# Altium schematic sheet pultboard_cpu.SchDoc(pultboard_cpu)
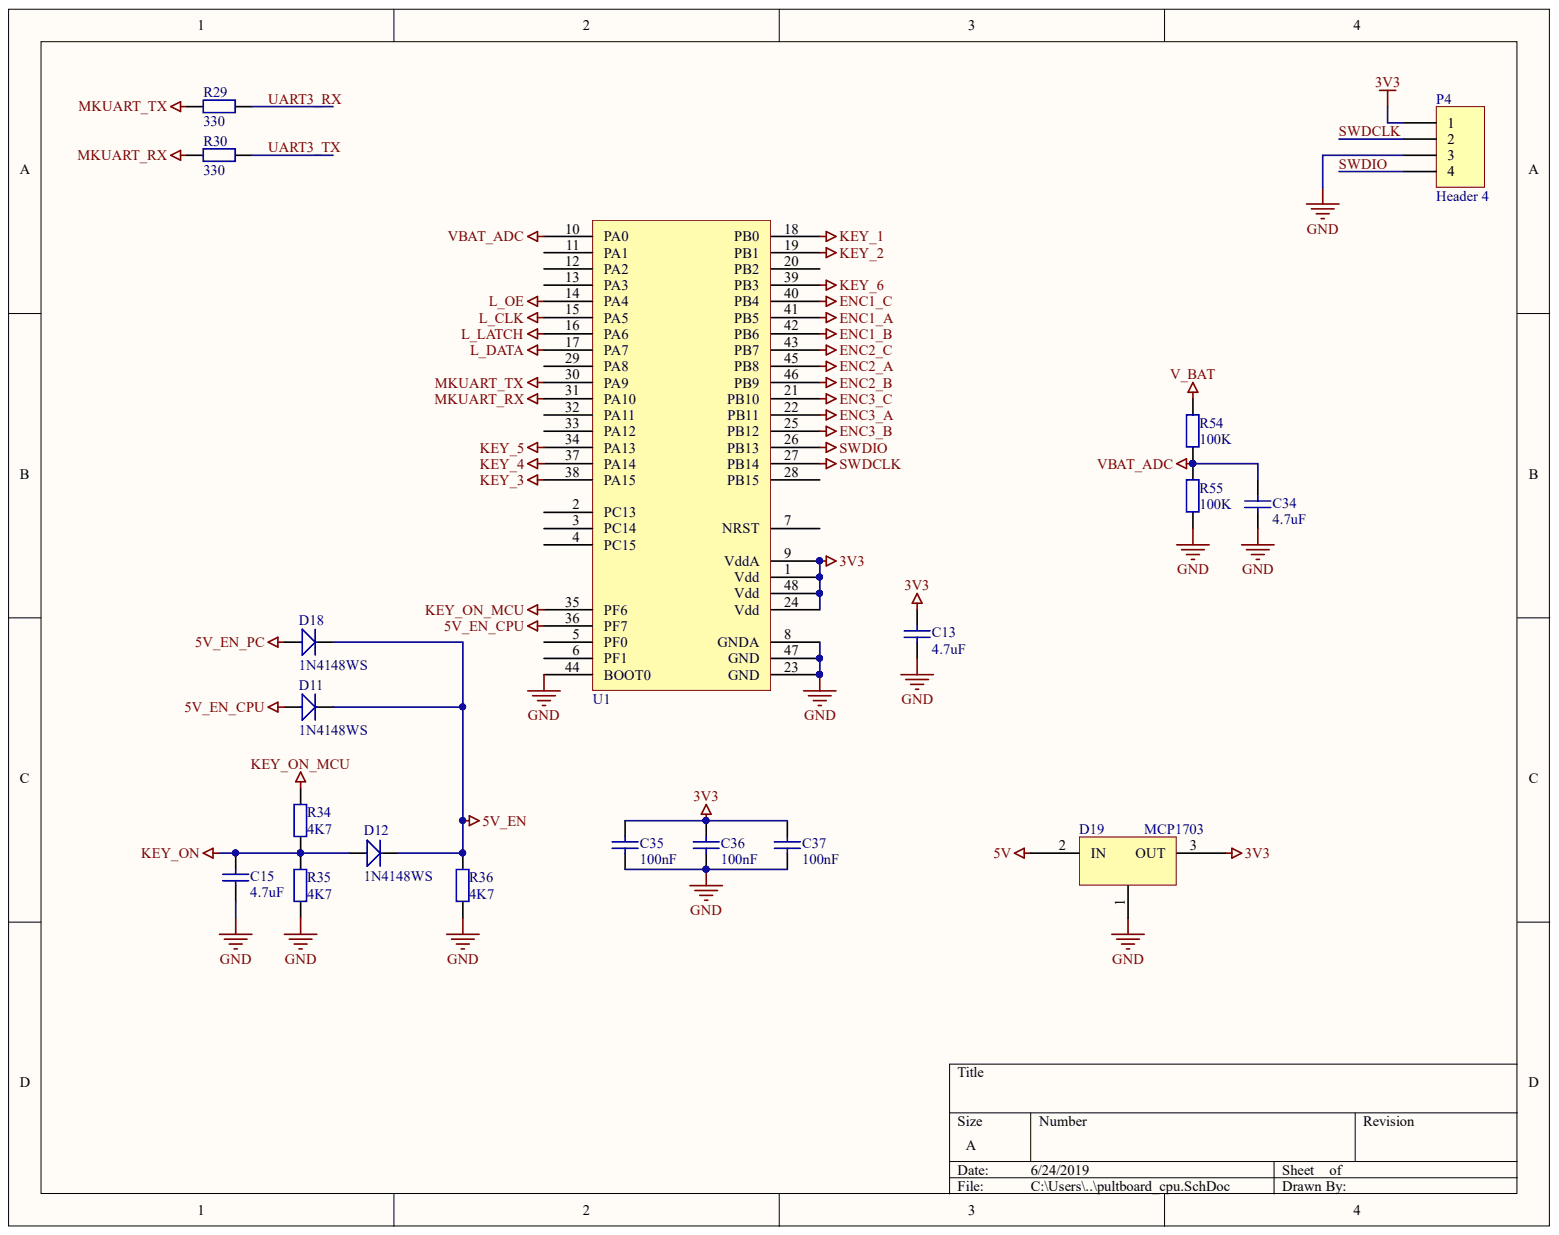
[diagram p0: pultboard_cpu.SchDoc]

## Parts
C13  value 4.7uF  [0603]  (hidden comment: Cap)
C15  value 4.7uF  [0603]  (hidden comment: Cap)
C34  value 4.7uF  [0603]  (hidden comment: Cap)
C35  value 100nF  [0402]  (hidden comment: Cap)
C36  value 100nF  [0402]  (hidden comment: Cap)
C37  value 100nF  [0402]  (hidden comment: Cap)
D11  1N4148WS  [SOD-323]
D12  1N4148WS  [SOD-323]
D18  1N4148WS  [SOD-323]
D19  MCP1703  [SOT89-150P409X160-3N]
P4  Header 4  [HDR1X4]
R29  value 330  [0603]  (hidden comment: Res)
R30  value 330  [0603]  (hidden comment: Res)
R34  value 4K7  [0603]  (hidden comment: Res)
R35  value 4K7  [0603]  (hidden comment: Res)
R36  value 4K7  [0603]  (hidden comment: Res)
R54  value 100K  [0603]  (hidden comment: Res)
R55  value 100K  [0603]  (hidden comment: Res)
U1  STM32F030C8T6  [LQFP48]  (value not drawn)

## Nets
3V3: C13.2 C35.2 C36.2 C37.2 D19.3 P4.1 U1.1(Vdd) U1.9(VddA) U1.24(Vdd) U1.48(Vdd)
5V: D19.2
5V_EN: D11.2 D12.2 D18.2 R36.2
5V_EN_CPU: D11.1 U1.36(PF7)
5V_EN_PC: D18.1
ENC1_A: U1.41(PB5)
ENC1_B: U1.42(PB6)
ENC1_C: U1.40(PB4)
ENC2_A: U1.45(PB8)
ENC2_B: U1.46(PB9)
ENC2_C: U1.43(PB7)
ENC3_A: U1.22(PB11)
ENC3_B: U1.25(PB12)
ENC3_C: U1.21(PB10)
GND: C13.1 C15.1 C34.1 C35.1 C36.1 C37.1 D19.1 P4.3 R35.1 R36.1 R55.1 U1.8(GNDA) U1.23(GND) U1.44(BOOT0) U1.47(GND)
KEY_1: U1.18(PB0)
KEY_2: U1.19(PB1)
KEY_3: U1.38(PA15)
KEY_4: U1.37(PA14)
KEY_5: U1.34(PA13)
KEY_6: U1.39(PB3)
KEY_ON: C15.2 D12.1 R34.1 R35.2
KEY_ON_MCU: R34.2 U1.35(PF6)
L_CLK: U1.15(PA5)
L_DATA: U1.17(PA7)
L_LATCH: U1.16(PA6)
L_OE: U1.14(PA4)
MKUART_RX: R30.1 U1.31(PA10)
MKUART_TX: R29.1 U1.30(PA9)
V_BAT: R54.2
VBAT_ADC: C34.2 R54.1 R55.2 U1.10(PA0)
SWDCLK: P4.2 U1.27(PB14)
SWDIO: P4.4 U1.26(PB13)
UART3_RX: R29.2
UART3_TX: R30.2

Not connected: U1 2(PC13) 3(PC14) 4(PC15) 5(PF0) 6(PF1) 7(NRST) 11(PA1) 12(PA2) 13(PA3) 20(PB2) 28(PB15) 29(PA8) 32(PA11) 33(PA12)

## Library data of the parts
C13 C15 C34 = GRM188R60J475KE19D@MURATA: Capacitor; Database Table Name: Capacitor ceramic; Tolerance: 10%; Voltage rated: 6V; Component Kind: Standard; Library Name: passive.DbLib; Link: http://www.elitan.ru/price/item605637; Package: 0603; Part Number: GRM188R60J475KE19D@MURATA; Supplier: elitan.ru
C35-C37 = 0402ZD104KAT2A@AVX: Capacitor; Database Table Name: Capacitor ceramic; Tolerance: 10%; Voltage rated: 10V; Component Kind: Standard; Library Name: passive.DbLib; Link: https://www.elitan.ru/price/item469194; Package: 0402; Part Number: 0402ZD104KAT2A@AVX; Supplier: elitan.ru
D11 D12 D18 = 1N4148WS@DIOTEC: Database Table Name: Diode tiny; Rated Voltage: 75V; Component Kind: Standard; Library Name: discrete.DbLib; Link: http://www.elitan.ru/price/item261580; Package: SOD-323 / 323; Part Number: 1N4148WS@DIOTEC; Supplier: elitan.ru
D19 = MCP1703T-3302E/MB@MICROCHIP: Linear Reg; Current: 0.25A; Database Table Name: Voltage Regulators - Linear; Voltage out: 3.3V; Component Kind: Standard; Library Name: generic ic.DbLib; Link: http://www.elitan.ru/price/item669279; Package: SOT-89; Part Number: MCP1703T-3302E/MB@MICROCHIP; Supplier: elitan.ru
P4 = Header 4: Header, 4-Pin; Component Kind: Standard; LatestRevisionDate: 17-Jul-2002; LatestRevisionNote: Re-released for DXP Platform.; Library Name: Miscellaneous Connectors.IntLib; Signal Integrity: Connector; Publisher: Altium Limited
R29 R30 = R_00024: Resistor; Tolerance: 5%; Component Kind: Standard; Database Table Name: Resistor 0603; Library Name: passive.DbLib; Package: 0603; Part Number: R_00024; Supplier: elitan.ru
R34-R36 = R_00042: Resistor; Tolerance: 5%; Component Kind: Standard; Database Table Name: Resistor 0603; Library Name: passive.DbLib; Package: 0603; Part Number: R_00042; Supplier: elitan.ru
R54 R55 = R_00065: Resistor; Tolerance: 5%; Component Kind: Standard; Database Table Name: Resistor 0603; Library Name: passive.DbLib; Package: 0603; Part Number: R_00065; Supplier: elitan.ru
U1 = STM32F030C8T6@ST: Database Table Name: MCU - STM32; Component Kind: Standard; Library Name: generic ic.DbLib; Link: http://www.elitan.ru/price/item10016714; Package: QFN48; Part Number: STM32F030C8T6@ST; Supplier: elitan.ru
